# Altium schematic sheet FlexEMG_v0r0_BATT-CHARGER.SchDoc
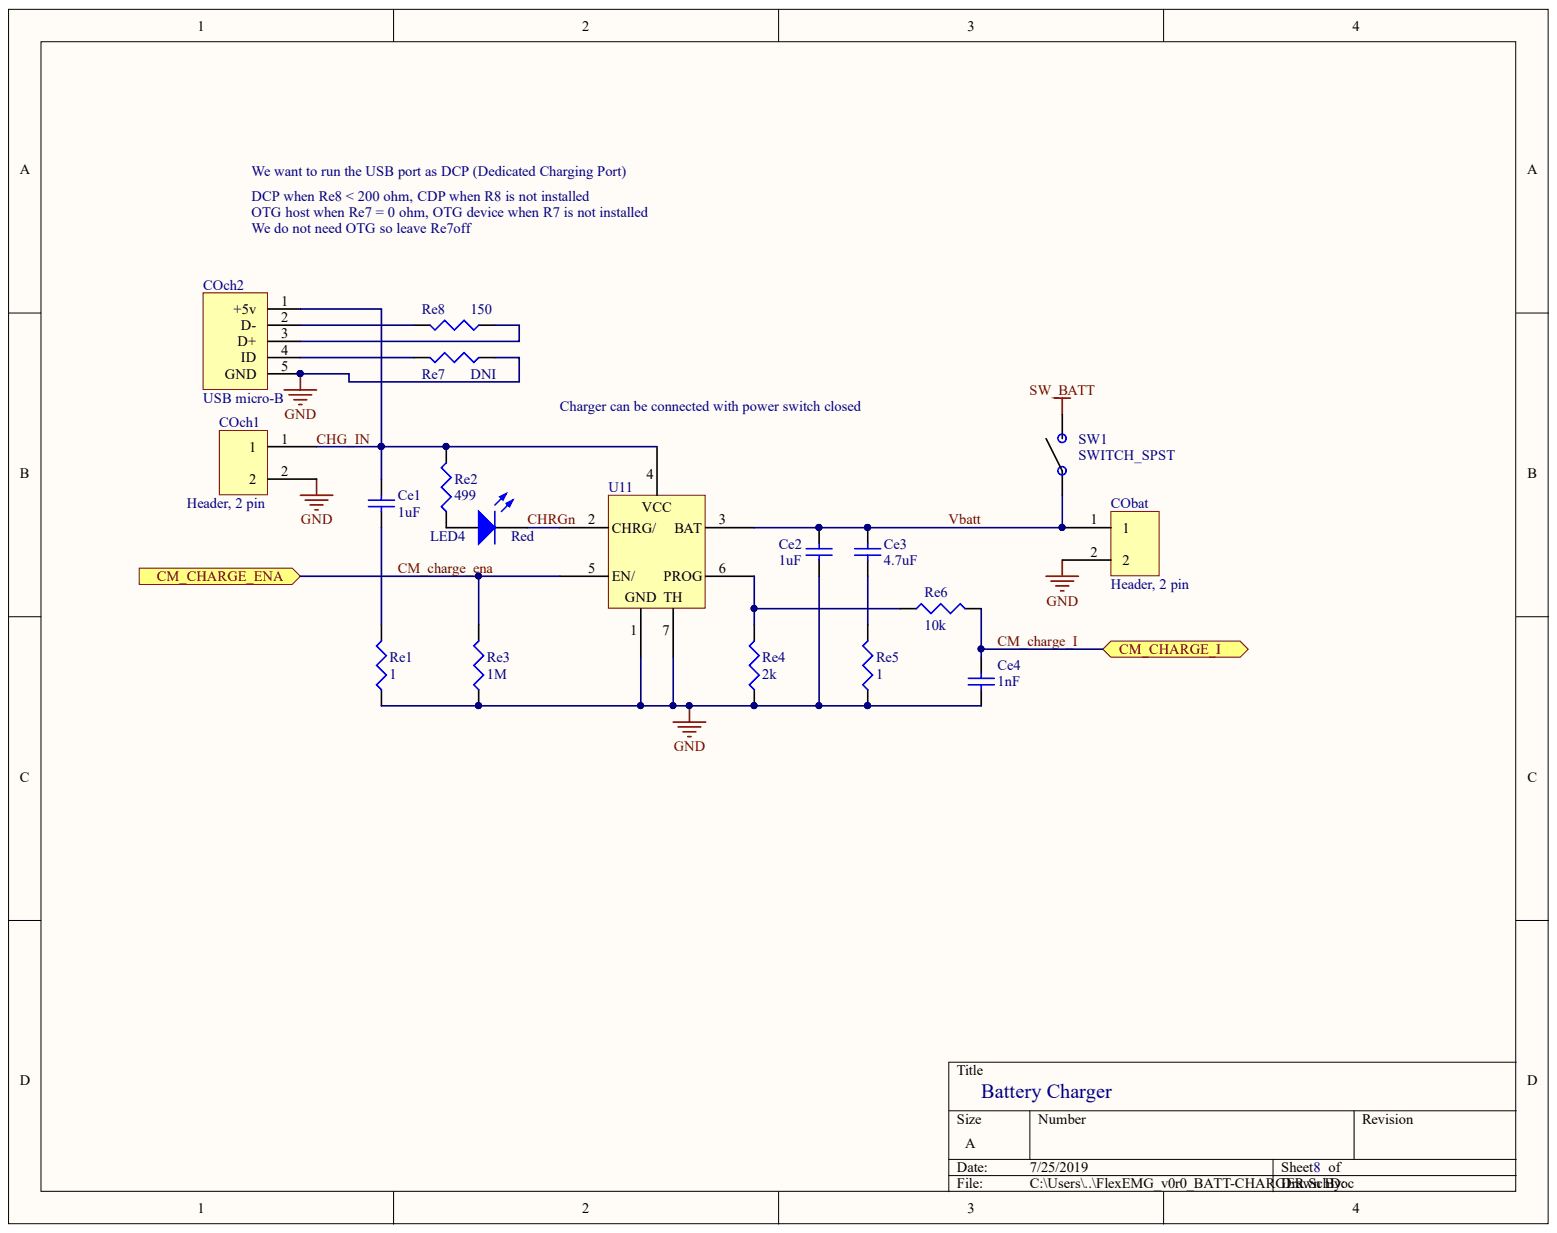
[diagram p0: FlexEMG_v0r0_BATT-CHARGER.SchDoc]

## Parts
Ce1  value 1uF  [c0402-TDK-C]  (hidden comment: C1005X5R1C105M050BC)
Ce2  value 1uF  [c0402-TDK-C]  (hidden comment: C1005X5R1C105M050BC)
Ce3  value 4.7uF  [c0603-Murata-GR]  (hidden comment: GRM188R6YA475KE15D)
Ce4  value 1nF  [c0402-Murata-GR]  (hidden comment: GRM155R61H102KA01D)
CObat  Header, 2 pin  [Header, 2 pin, 2mm(79mil)]
COch1  Header, 2 pin  [Header, 2 pin, 2mm(79mil)]
COch2  USB micro-B  [USB-microB_amp_10118194-0001LF]
LED4  Color: Red  [LED-0603]  (hidden comment: Manufactrurer PN)
Re1  value 1  [0402_p]  (hidden comment: ERJ-2BQF1R0X)
Re2  value 499  [0402_p]  (hidden comment: ERJ-2RKF4990X)
Re3  value 1M  [0402_p]  (hidden comment: ERJ-2RKF1004X)
Re4  value 2k  [0402_p]  (hidden comment: ERJ-2RKF2001X)
Re5  value 1  [0402_p]  (hidden comment: ERJ-2BQF1R0X)
Re6  value 10k  [0402_p]  (hidden comment: ERJ-2RKF1002X)
Re7  DNI  [0402_p]
Re8  value 150  [0402_p]  (hidden comment: ERJ-PA2J151X)
SW1  SWITCH_SPST  [SWITCH_SPST_CHS-01TB]
U11  LTC4065EDC#TRMPBF  [DFN-6_LT]  (value not drawn)

## Ports
CM_CHARGE_ENA, CM_CHARGE_I

## Nets
GND: Ce2.1 Ce4.1 CObat.2 COch1.2 COch2.5(GND) Re1.1 Re3.1 Re4.1 Re5.1 Re7.1 U11.1 U11.7(TH)
SW_BATT: SW1.1
CHG_IN: Ce1.2 COch1.1 COch2.1(+5v) Re2.2 U11.4
CHRGN: LED4.2 U11.2(CHRG/)
CM_CHARGE_ENA: Re3.2 U11.5(EN/) ; port CM_CHARGE_ENA
CM_CHARGE_I: Ce4.2 Re6.2 ; port CM_CHARGE_I
VBATT: Ce2.2 Ce3.2 CObat.1 SW1.2 U11.3(BAT)
NetCe1_1: Ce1.1 Re1.2
NetCe3_1: Ce3.1 Re5.2
NetCOch2_2: COch2.2(D-) Re8.2
NetCOch2_3: COch2.3(D+) Re8.1
NetCOch2_4: COch2.4(ID) Re7.2
NetLED4_1: LED4.1 Re2.1
NetRe4_2: Re4.2 Re6.1 U11.6(PROG)

## Library data of the parts
Ce1 Ce2 = Cap: CAP CER 1UF 16V X5R 0402; Manufacturer: TDK; Component Kind: Standard; Library Name: Custom.IntLib; Manufacturer PN: C1005X5R1C105M050BC; Signal Integrity: Cap; Simulation: CAP; Supplier: Digikey; Supplier PN: 445-9073-1-ND
Ce3 = Cap: CAP CER 4.7UF 35V X5R 0603; Manufacturer: Murata; Component Kind: Standard; Library Name: Custom.IntLib; Manufacturer PN: GRM188R6YA475KE15D; Signal Integrity: Cap; Simulation: CAP; Supplier: Digikey; Supplier PN: 490-7205-1-ND
Ce4 = Cap: CAP CER 1000PF 50V X5R 0402; Manufacturer: Murata; Component Kind: Standard; Library Name: Custom.IntLib; Manufacturer PN: GRM155R61H102KA01D; Signal Integrity: Cap; Simulation: CAP; Supplier: Digikey; Supplier PN: 490-6316-1-ND
CObat COch1 = Header, 2 pin: Header 1x2; Manufacturer: Samtec; Manufacturer PN: TMM-102-03-T-S; Component Kind: Standard; Library Name: CM.SchLib; Supplier: Digikey; Supplier PN: SAM8977-ND
COch2 = USB-microB: USB micro-B connector; Manufacturer: Amphenol; Manufacturer PN: 10118194-0001LF; Component Kind: Standard; Library Name: CM.SchLib; Supplier: Digikey; Supplier PN: 609-4618-1-ND
LED4 = LED: LED RED CLEAR 0603 SMD; Manufacturer: Rohm; Manufacturer PN: SML-311UTT86; Component Kind: Standard; Library Name: Custom.SchLib; Supplier: Digikey; Supplier PN: 511-1304-1-ND
Re1 Re5 = Resistor: RES SMD 1 OHM 1% 1/6W 0402; Manufacturer: Panasonic; Component Kind: Standard; Library Name: Custom.SchLib; Manufacturer PN: ERJ-2BQF1R0X; Package: 0402; Power: 1/6W; Signal Integrity: Res; Simulation: RESISTOR; Supplier: Digikey; Supplier P/N: P17252CT-ND
Re2 = Resistor: RES SMD 499 OHM 1% 1/10W 0402; Manufacturer: Panasonic; Component Kind: Standard; Library Name: Custom.SchLib; Manufacturer PN: ERJ-2RKF4990X; Package: 0402; Power: 1/10W; Signal Integrity: Res; Simulation: RESISTOR; Supplier: Digikey; Supplier P/N: P499LCT-ND
Re3 = Resistor: RES SMD 1M OHM 1% 1/10W 0402; Manufacturer: Panasonic; Component Kind: Standard; Library Name: Custom.SchLib; Manufacturer PN: ERJ-2RKF1004X; Package: 0402; Power: 1/10W; Signal Integrity: Res; Simulation: RESISTOR; Supplier: Digikey; Supplier P/N: P1.00MLCT-ND
Re4 = Resistor: RES SMD 2K OHM 1% 1/10W 0402; Manufacturer: Panasonic; Component Kind: Standard; Library Name: Custom.SchLib; Manufacturer PN: ERJ-2RKF2001X; Package: 0402; Power: 1/10W; Signal Integrity: Res; Simulation: RESISTOR; Supplier: Digikey; Supplier P/N: P2.00KLCT-ND
Re6 = Resistor: RES SMD 10K OHM 1% 1/10W 0402; Manufacturer: Panasonic; Component Kind: Standard; Library Name: Custom.SchLib; Manufacturer PN: ERJ-2RKF1002X; Package: 0402; Power: 1/10W; Signal Integrity: Res; Simulation: RESISTOR; Supplier: Digikey; Supplier P/N: P10.0KLCT-ND
Re7 = Resistor: Manufacturer: Panasonic; Power: 1/10W; Component Kind: Standard; Description: Resistor; Library Name: Custom.SchLib; Manufacturer PN: DNI; Package: 0402; Signal Integrity: Res; Simulation: RESISTOR; Supplier: Digikey
Re8 = Resistor: RES SMD 150 OHM 5% 1/5W 0402; Manufacturer: Panasonic; Power: 1/10W; Component Kind: Standard; Library Name: Custom.SchLib; Manufacturer PN: ERJ-PA2J151X; Package: 0402; Signal Integrity: Res; Simulation: RESISTOR; Supplier: Digikey; Supplier P/N: P17188CT-ND
SW1 = SWITCH_SPST: SWITCH SLIDE DIP SPST 100MA 6V; Manufacturer: Copal; Manufacturer PN: CHS-01TB; Component Kind: Standard; Library Name: CM.SchLib; Supplier: Digikey; Supplier PN: 563-1004-1-ND
U11 = LTC4065EDC: IC CHARGER BATT LI-ON 6-DFN; Manufacturer: LT; Note: 4.4v float version is available (4.2 is standard): LTC4065EDC-4.4; Component Kind: Standard; Library Name: Custom.SchLib; Manufacturer PN: LTC4065EDC#TRMPBF; Supplier: Digikey; Supplier PN: LTC4065EDC#TRMPBFCT-ND
